# Altium schematic sheet INCUBATION.SchDoc
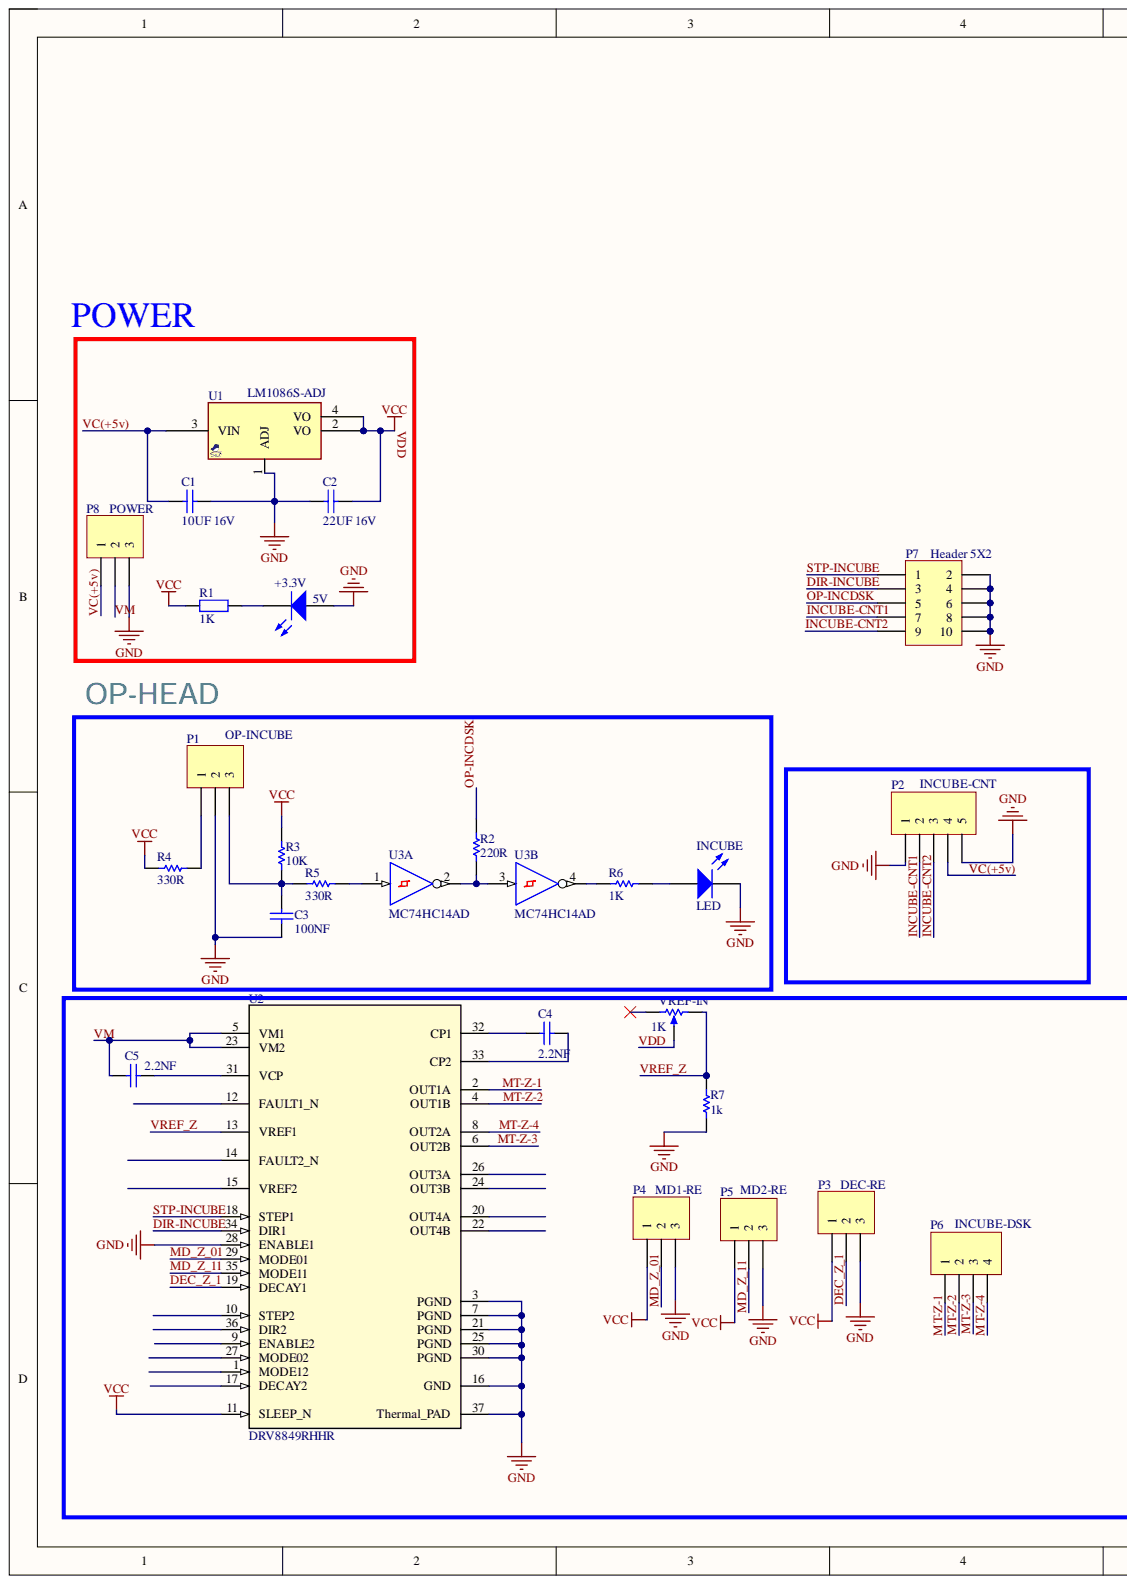
[diagram p0: INCUBATION.SchDoc, left]
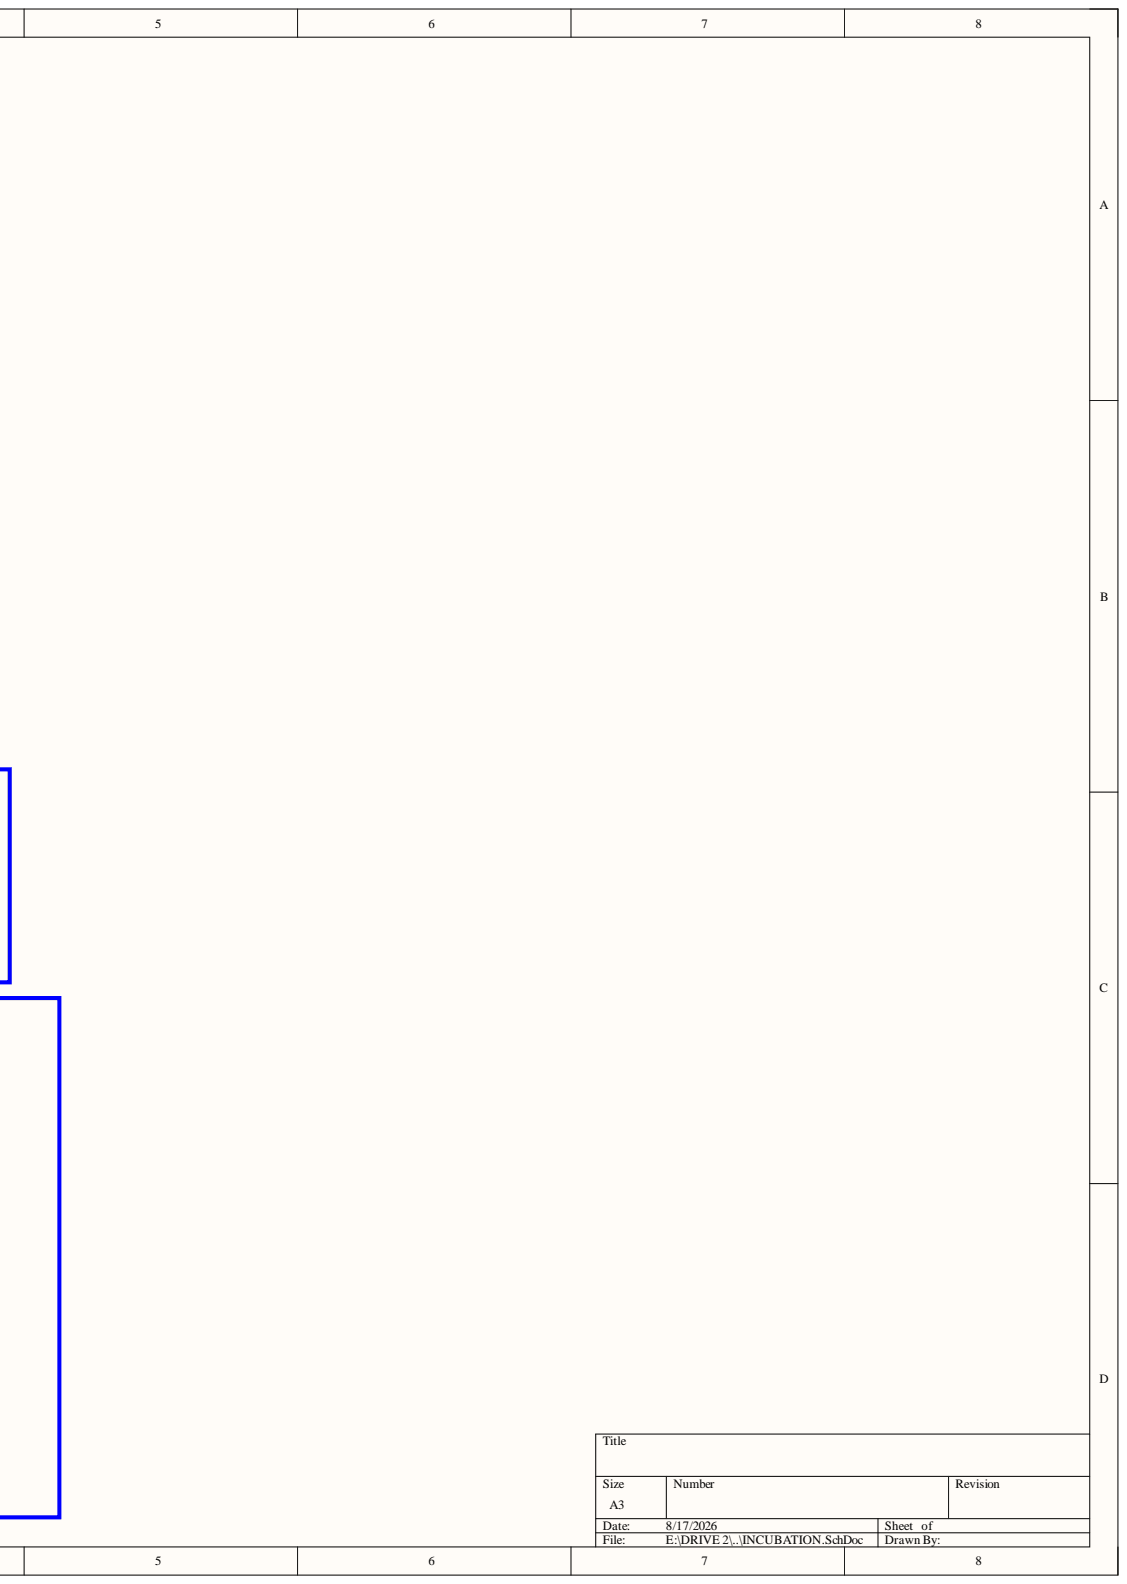
[diagram p1: INCUBATION.SchDoc, right]

## Parts
+3.3V  5V  [LED1206YLW]
C1  10UF 16V  [C_EL_8]
C2  22UF 16V  [C_EL_5]
C3  100NF  [C1206E]
C4  2.2NF  [C1206E]
C5  2.2NF  [C1206E]
INCUBE  LED  [LED1206BLU]
P1  OP-INCUBE  [XH_3]
P2  INCUBE-CNT  [XH_5]
P3  DEC-RE  [JP_3]
P4  MD1-RE  [JP_3]
P5  MD2-RE  [JP_3]
P6  INCUBE-DSK  [XH_4]
P7  Header 5X2  [IDC_2X5]
P8  POWER  [PWL_3]
R1  1K  [R1206E]
R2  220R  [R1206E]
R3  10K  [R1206E]
R4  330R  [R1206E]
R5  330R  [R1206E]
R6  1K  [R1206E]
R7  1k  [R1206E]
U1  LM1086S-ADJ  [D2PAK_3]
U2  DRV8849RHHR  [DRV8849-D]
U3  MC74HC14AD  [SO_14]  (parts A,B)
VREF-IN  1K  [POT_3306F]

## Nets
GND: +3.3V.1 C1.2 C2.2 C3.1 INCUBE.2 P1.2 P2.1 P2.5 P3.3 P4.3 P5.3 P7.2 P7.4 P7.6 P7.8 P7.10 P8.3 R7.2 U1.1(ADJ) U2.3(PGND) U2.7(PGND) U2.16(GND) U2.21(PGND) U2.25(PGND) U2.28(ENABLE1) U2.30(PGND) U2.37(Thermal_PAD) U3.7
DEC_Z_1: P3.2 U2.19(DECAY1)
DIR-INCUBE: P7.3 U2.34(DIR1)
INCUBE-CNT1: P2.2 P7.7
INCUBE-CNT2: P2.3 P7.9
MD_Z_01: P4.2 U2.29(MODE01)
MD_Z_11: P5.2 U2.35(MODE11)
MT-Z-1: P6.1 U2.2(OUT1A)
MT-Z-2: P6.2 U2.4(OUT1B)
MT-Z-3: P6.3 U2.6(OUT2B)
MT-Z-4: P6.4 U2.8(OUT2A)
OP-INCDSK: P7.5 R2.2
STP-INCUBE: P7.1 U2.18(STEP1)
VC(+5v): C1.1 P2.4 P8.1 U1.3(VIN)
VDD: C2.1 P3.1 P4.1 P5.1 R1.1 R3.2 R4.2 U1.2(VO) U1.4(VO) U2.11(SLEEP_N) U3.14 VREF-IN.3
VM: C5.2 P8.2 U2.5(VM1) U2.23(VM2)
VREF_Z: R7.1 U2.13(VREF1) VREF-IN.2
Net+3.3V_2: +3.3V.2 R1.2
NetC3_2: C3.2 P1.3 R3.1 R5.1
NetC4_1: C4.1 U2.33(CP2)
NetC4_2: C4.2 U2.32(CP1)
NetC5_1: C5.1 U2.31(VCP)
NetINCUBE_1: INCUBE.1 R6.2
NetP1_1: P1.1 R4.1
NetR2_1: R2.1 U3.2 U3.3
NetR5_2: R5.2 U3.1
NetR6_1: R6.1 U3.4

Not connected: U2 1(MODE12) 9(ENABLE2) 10(STEP2) 12(FAULT1_N) 14(FAULT2_N) 15(VREF2) 17(DECAY2) 20(OUT4A) 22(OUT4B) 24(OUT3B) 26(OUT3A) 27(MODE02) 36(DIR2); VREF-IN 1

## Library data of the parts
+3.3V INCUBE = LED0: Typical INFRARED GaAs LED; Component Kind: Standard; LatestRevisionDate: 08-Jul-2005; LatestRevisionNote: Mech Layer 1 removed.; Library Name: Miscellaneous Devices.IntLib; PackageReference: LED-0; Published: 8-Jun-2000; Simulation: LED0; Publisher: Altium Limited
  INCUBE: PackageDescription: LED; 2 Leads
C1-C5 = Cap: Capacitor; Component Kind: Standard; LatestRevisionDate: 17-Jul-2002; LatestRevisionNote: Re-released for DXP Platform.; Library Name: Miscellaneous Devices.IntLib; PackageDescription: Radial Cap, Thru-Hole; 2 Leads; 0.3 in Pin Spacing; PackageReference: RAD-0.3; Published: 8-Jun-2000; Signal Integrity: Cap; Simulation: CAP; Publisher: Altium Limited
  C1: Value: 10UF 16V
  C2: Value: 22UF 16V
  C3: Value: 100NF
  C4 C5: Value: 2.2NF
P1 P3-P5 P8 = Header 3: Header, 3-Pin; Component Kind: Standard; LatestRevisionDate: 17-Jul-2002; LatestRevisionNote: Re-released for DXP Platform.; Library Name: Miscellaneous Connectors.IntLib; Signal Integrity: Connector; Publisher: Altium Limited
P2 = Header 5: Header, 5-Pin; Component Kind: Standard; LatestRevisionDate: 17-Jul-2002; LatestRevisionNote: Re-released for DXP Platform.; Library Name: Miscellaneous Connectors.IntLib; Signal Integrity: Connector; Publisher: Altium Limited
P6 = Header 4: Header, 4-Pin; Component Kind: Standard; LatestRevisionDate: 17-Jul-2002; LatestRevisionNote: Re-released for DXP Platform.; Library Name: Miscellaneous Connectors.IntLib; Signal Integrity: Connector; Publisher: Altium Limited
P7 = Header 5X2: Header, 5-Pin, Dual row; Component Kind: Standard; LatestRevisionDate: 17-Jul-2002; LatestRevisionNote: Re-released for DXP Platform.; Library Name: Miscellaneous Connectors.IntLib; Signal Integrity: Connector; Publisher: Altium Limited
R1 = Res2: Resistor; Component Kind: Standard; LatestRevisionDate: 17-Jul-2002; LatestRevisionNote: Re-released for DXP Platform.; Library Name: Miscellaneous Devices.IntLib; PackageReference: AXIAL-0.4; Published: 8-Jun-2000; Signal Integrity: Res; Simulation: RESISTOR; Value: 1K; Publisher: Altium Limited
R2-R7 = Res1: Resistor; Component Kind: Standard; LatestRevisionDate: 17-Jul-2002; LatestRevisionNote: Re-released for DXP Platform.; Library Name: Miscellaneous Devices.IntLib; PackageDescription: Axial Device, Thru-Hole; 2 Leads; 0.3 in Pin Spacing; PackageReference: AXIAL-0.3; Published: 8-Jun-2000; Signal Integrity: Res; Simulation: RESISTOR; Publisher: Altium Limited
  R2: Value: 220R
  R3: Value: 10K
  R4 R5: Value: 330R
  R6: Value: 1K
  R7: Value: 1k
U1 = VREG_1086_TO263: REG, Linear, LDO1.3, Adj, 1.5A, TO-263; MANF: TI, ST, DIODES; MANF_P#: LM1086CS-ADJ, LM1086IS-ADJ, AZ1086S-ADJ; PARAM2: 2.8~30VIN, 1.3VDROP, 1.25VREF; Component Kind: Standard; Library Name: reg_linear_108x.SchLib; PARAM: 1.5A; VALUE: LM1086S-ADJ
U2 = DRV8849RHHR: No Description Available; Mfr_Name: Texas Instruments; Component Kind: Standard; Library Name: DRV8849RHHR.SchLib; RefDes: RefDes; TYPE: TYPE
U3 = MC74HC14AD: Hex Schmitt Trigger Inverter; Code_IPC: SOIC127P600-14; Component Kind: Standard; ComponentLink1Description: Manufacturer Link; ComponentLink1URL: http://www.onsemi.com/site/products/parametrics/0,4446,247,00.html; ComponentLink2Description: Ibis Model; ComponentLink2URL: http://www.onsemi.com/pub/Collateral/MC54HC14AJ.IBS; DatasheetVersion: 1989; LatestRevisionDate: 13-Apr-2006; LatestRevisionNote: IPC-7351 Footprint Added.; Library Name: Motorola Logic Gate.IntLib; PackageDescription: 14-Pin Small Outline Integrated Circuit 1.27 mm Pitch; PackageReference: 751A-02; PackageVersion: 1991; PCB3D: 751A-02; Published: 17-Jul-2002; Signal Integrity: MC74HC14AD; Publisher: Altium Limited
VREF-IN = RPot: Potentiometer; Set Position: 0.5; Sim Note: Enter the Resistance value and the set point into parameter fields.; Component Kind: Standard; LatestRevisionDate: 17-Jul-2002; LatestRevisionNote: Re-released for DXP Platform.; Library Name: Miscellaneous Devices.IntLib; PackageDescription: Variable Resistor, Thru-Hole; 3 Leads; PackageReference: VR5; Published: 8-Jun-2000; Simulation: POT; Value: 1K; Publisher: Altium Limited
